# Altium schematic sheet Input.SchDoc(Input)
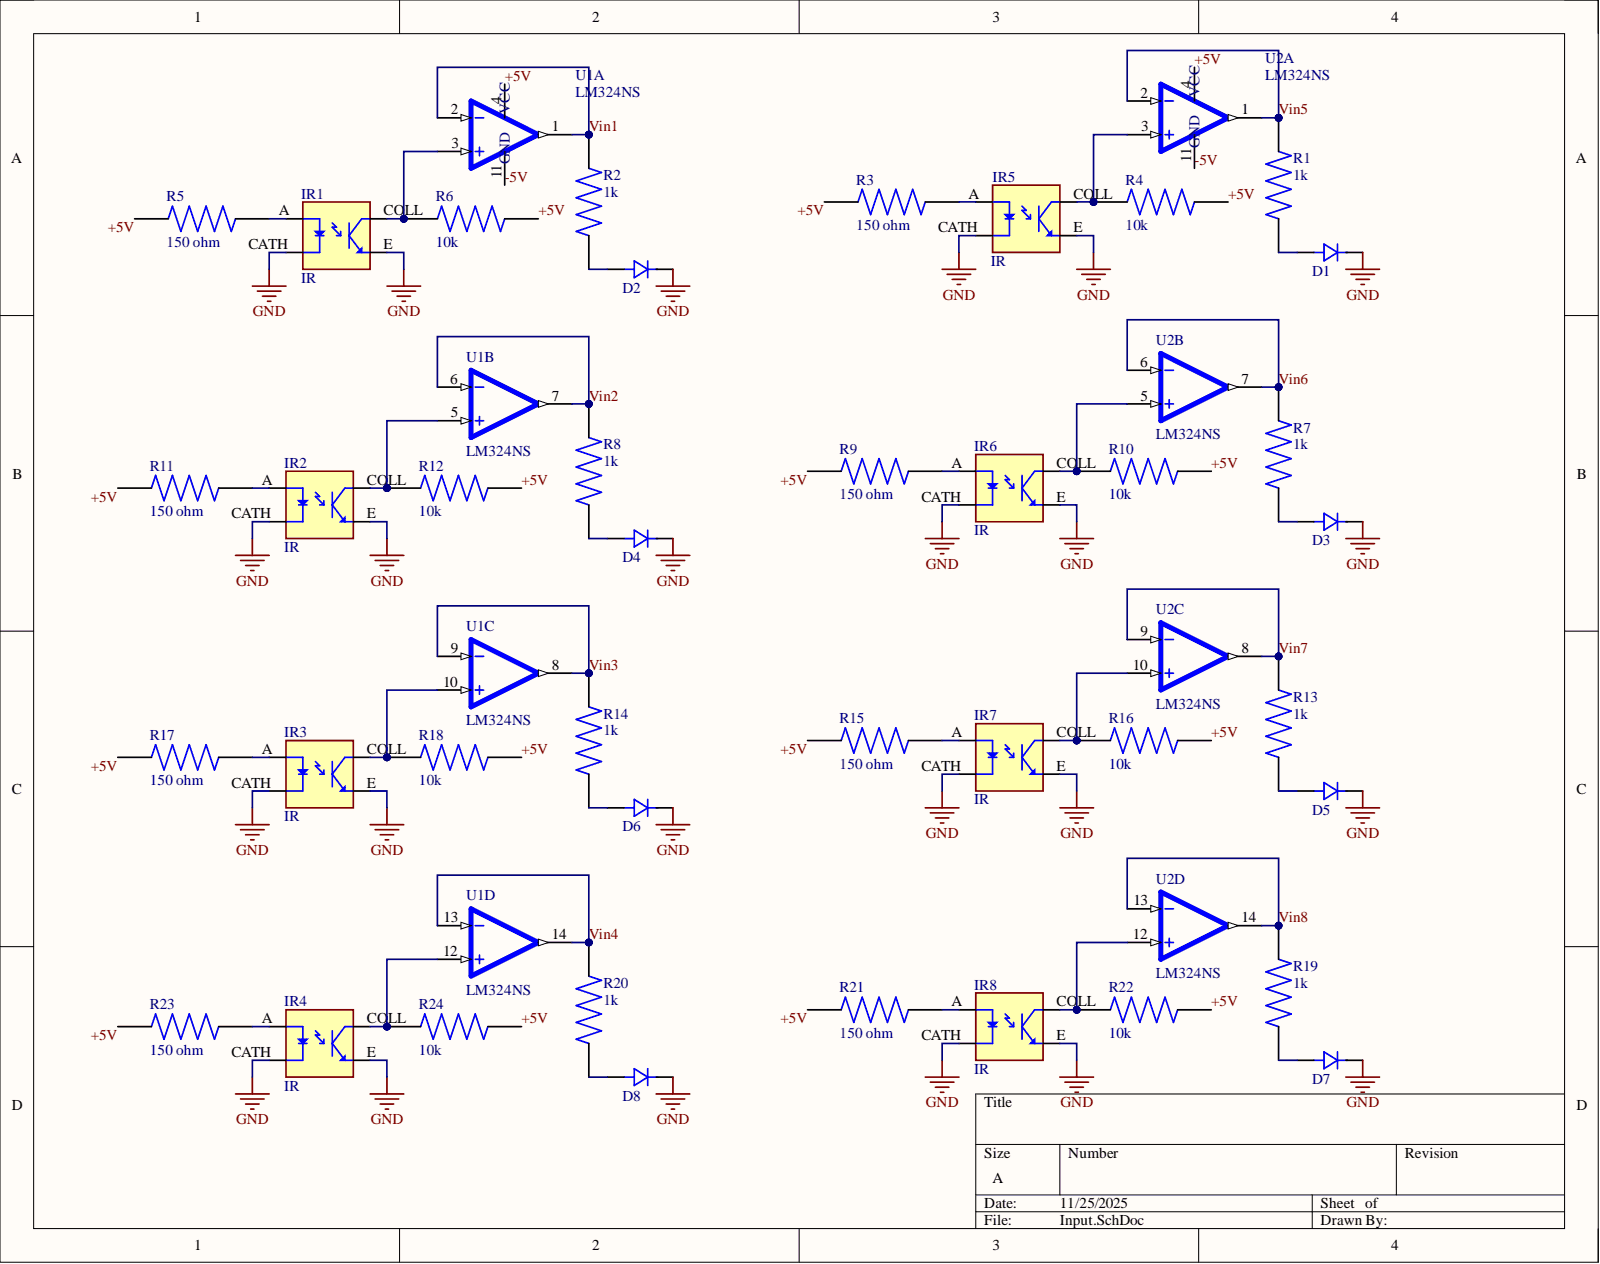
[diagram p0: Input.SchDoc]

## Parts
D1  150080RS75000  (value not drawn)
D2  150080RS75000  (value not drawn)
D3  150080RS75000  (value not drawn)
D4  150080RS75000  (value not drawn)
D5  150080RS75000  (value not drawn)
D6  150080RS75000  (value not drawn)
D7  150080RS75000  (value not drawn)
D8  150080RS75000  (value not drawn)
IR1  IR
IR2  IR
IR3  IR
IR4  IR
IR5  IR
IR6  IR
IR7  IR
IR8  IR
R1  1k
R2  1k
R3  150 ohm
R4  10k
R5  150 ohm
R6  10k
R7  1k
R8  1k
R9  150 ohm
R10  10k
R11  150 ohm
R12  10k
R13  1k
R14  1k
R15  150 ohm
R16  10k
R17  150 ohm
R18  10k
R19  1k
R20  1k
R21  150 ohm
R22  10k
R23  150 ohm
R24  10k
U1  LM324NS  (parts A,B,C,D)
U2  LM324NS  (parts A,B,C,D)

## Nets
GND: D1.2 D2.2 D3.2 D4.2 D5.2 D6.2 D7.2 D8.2 IR1.CATH IR1.E IR2.CATH IR2.E IR3.CATH IR3.E IR4.CATH IR4.E IR5.CATH IR5.E IR6.CATH IR6.E IR7.CATH IR7.E IR8.CATH IR8.E
+5V: R3.1 R4.2 R5.1 R6.2 R9.1 R10.2 R11.1 R12.2 R15.1 R16.2 R17.1 R18.2 R21.1 R22.2 R23.1 R24.2 U1.4(GND) U2.4(GND)
-5V: U1.11 U2.11
Vin1: R2.2 U1.1 U1.2
Vin2: R8.2 U1.6 U1.7
Vin3: R14.2 U1.8 U1.9
Vin4: R20.2 U1.13 U1.14
Vin5: R1.2 U2.1 U2.2
Vin6: R7.2 U2.6 U2.7
Vin7: R13.2 U2.8 U2.9
Vin8: R19.2 U2.13 U2.14
NetD1_1: D1.1 R1.1
NetD2_1: D2.1 R2.1
NetD3_1: D3.1 R7.1
NetD4_1: D4.1 R8.1
NetD5_1: D5.1 R13.1
NetD6_1: D6.1 R14.1
NetD7_1: D7.1 R19.1
NetD8_1: D8.1 R20.1
NetIR1_A: IR1.A R5.2
NetIR1_COLL: IR1.COLL R6.1 U1.3
NetIR2_A: IR2.A R11.2
NetIR2_COLL: IR2.COLL R12.1 U1.5
NetIR3_A: IR3.A R17.2
NetIR3_COLL: IR3.COLL R18.1 U1.10
NetIR4_A: IR4.A R23.2
NetIR4_COLL: IR4.COLL R24.1 U1.12
NetIR5_A: IR5.A R3.2
NetIR5_COLL: IR5.COLL R4.1 U2.3
NetIR6_A: IR6.A R9.2
NetIR6_COLL: IR6.COLL R10.1 U2.5
NetIR7_A: IR7.A R15.2
NetIR7_COLL: IR7.COLL R16.1 U2.10
NetIR8_A: IR8.A R21.2
NetIR8_COLL: IR8.COLL R22.1 U2.12

## Library data of the parts
IR1-IR8 = IR: 950 nm 60 mA Through Hole Reflective Optical Sensor with Transistor Output; TCRT5000; Availability: Unavailable; Component Kind: Standard; MP: TCRT5000; Package: None; Price: None; Library Name: irs.IntLib
R1 R2 R7 R8 R13 R14 R19 R20 = 1k: 1 kOhms Â±1% 0.125W, 1/8W Chip Resistor 0805 (2012 Metric) Moisture Resistant Thick Film; RC0805FR-071KL; MF: Yageo; Check_prices: https://www.snapeda.com/parts/RC0805FR-071KL/Yageo/view-part/?ref=eda; Component Kind: Standard; DigiKey_Part_Number: 311-1.00KCRTR-ND; Library Name: 1ks.IntLib; MP: RC0805FR-071KL; Package: 2012 Yageo; Purchase-URL: https://pricing.snapeda.com/search?q=RC0805FR-071KL&ref=eda; SnapEDA_Link: https://www.snapeda.com/parts/RC0805FR-071KL/Yageo/view-part/?ref=snap
R3 R5 R9 R11 R15 R17 R21 R23 = 150 ohm: 150 Ohms Â±1% 0.125W, 1/8W Chip Resistor 0805 (2012 Metric) Moisture Resistant Thick Film; RC0805FR-07150RL; MF: Yageo; Check_prices: https://www.snapeda.com/parts/RC0805FR-07150RL/Yageo/view-part/?ref=eda; Component Kind: Standard; Library Name: 150 ohm.IntLib; MP: RC0805FR-07150RL; Package: 2012 Yageo; SnapEDA_Link: https://www.snapeda.com/parts/RC0805FR-07150RL/Yageo/view-part/?ref=snap
R4 R6 R10 R12 R16 R18 R22 R24 = 10k: 10 kOhms Â±1% 0.125W, 1/8W Chip Resistor 0805 (2012 Metric) Moisture Resistant Thick Film; RC0805FR-0710KL; MF: Yageo; Availability: In Stock; Check_prices: https://www.snapeda.com/parts/RC0805FR-0710KL/Yageo/view-part/?ref=eda; Component Kind: Standard; Library Name: 10ks.IntLib; MP: RC0805FR-0710KL; Package: 2012 Rohm Semiconductor; Price: None; Purchase-URL: https://pricing.snapeda.com/search/part/RC0805FR-0710KL/?ref=eda; SnapEDA_Link: https://www.snapeda.com/parts/RC0805FR-0710KL/Yageo/view-part/?ref=snap
U1 U2 = LM324NS: 4-Channel industry standard operational amplifier 14-SO 0 to 70; LM324NSRG4; MF: Texas Instruments; Availability: In Stock; Check_prices: https://www.snapeda.com/parts/LM324NSRG4/Texas+Instruments/view-part/?ref=eda; Component Kind: Standard; Library Name: LM324NSRG4.IntLib; MP: LM324NSRG4; Package: SOP-14 Texas Instruments; Price: None; Purchase-URL: https://pricing.snapeda.com/search/part/LM324NSRG4/?ref=eda; SnapEDA_Link: https://www.snapeda.com/parts/LM324NSRG4/Texas+Instruments/view-part/?ref=snap
D1-D8 = 150080RS75000: Component Kind: Standard; Library Name: Integrated_Library_analog_project.IntLib
